# Altium schematic sheet 6-Bank6-9.schdoc(6-Bank6-9)
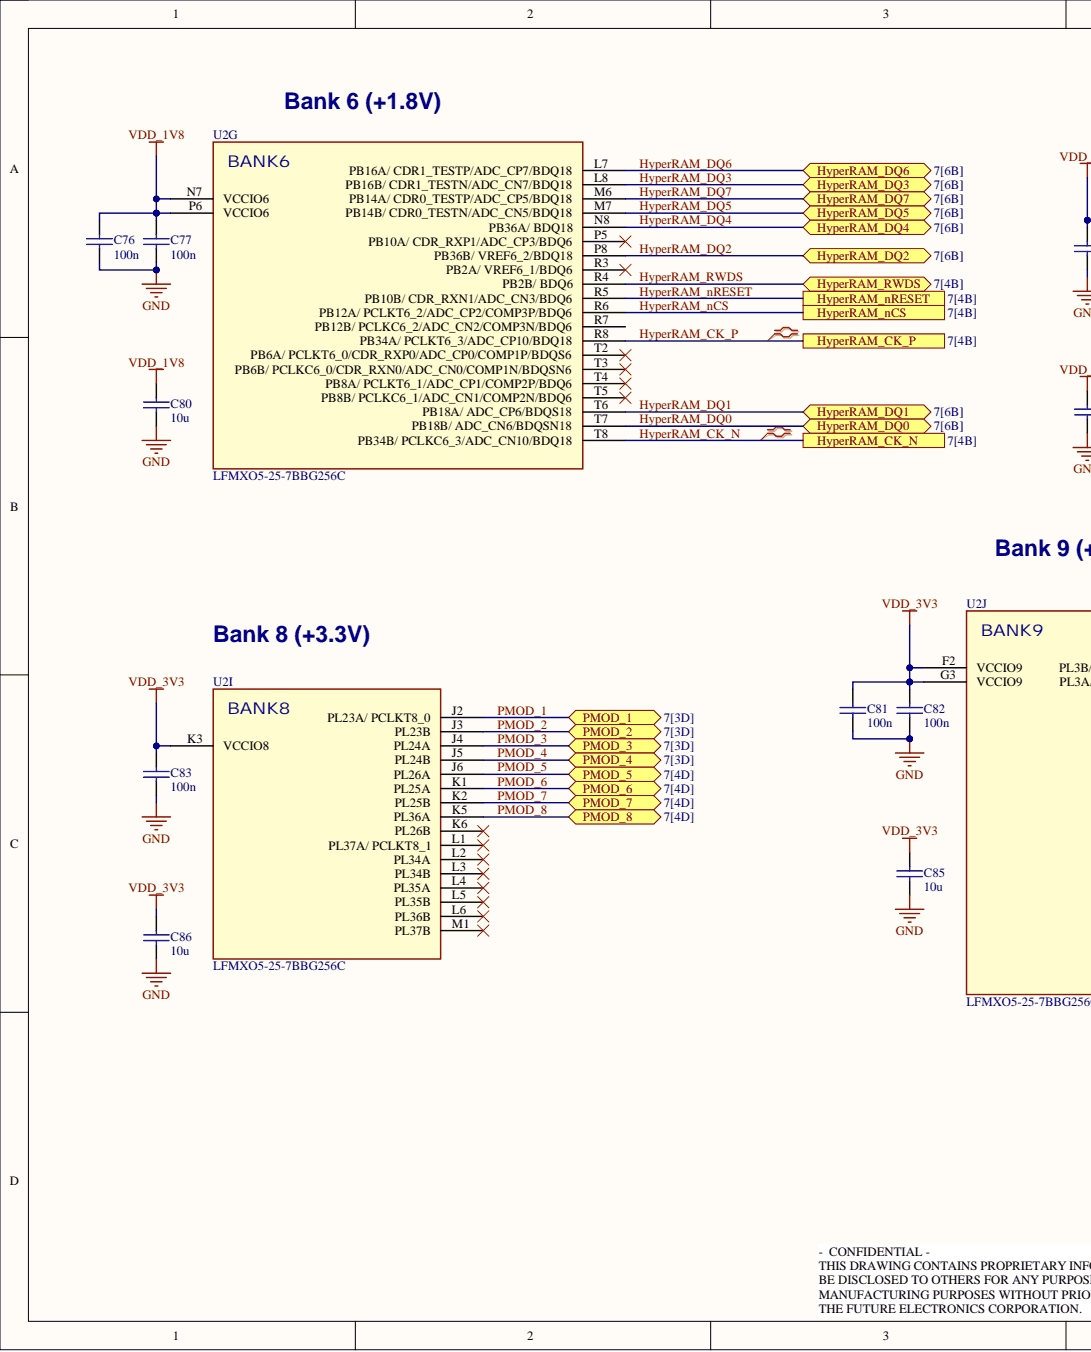
[diagram p0: 6-Bank6-9.schdoc, left]
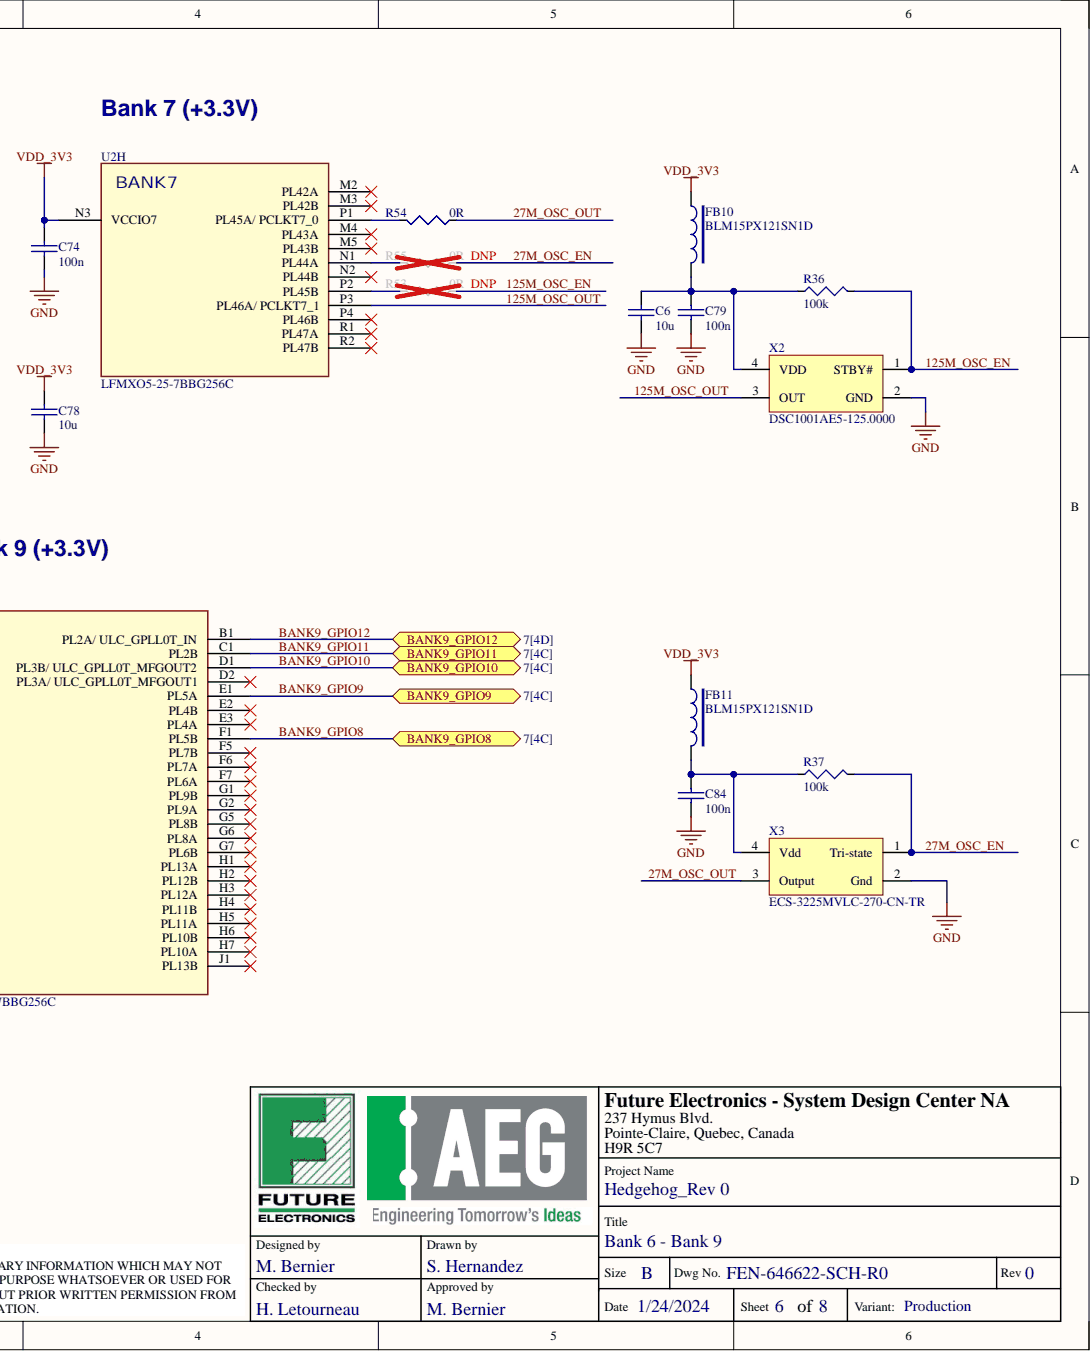
[diagram p1: 6-Bank6-9.schdoc, right]

## Parts
C6  value 10u  [1206]  (hidden comment: C-10uF-50V-Cer-1206_A)
C74  value 100n  [0402]  (hidden comment: C-100nF-50V-Cer-0402)
C76  value 100n  [0402]  (hidden comment: C-100nF-50V-Cer-0402)
C77  value 100n  [0402]  (hidden comment: C-100nF-50V-Cer-0402)
C78  value 10u  [1206]  (hidden comment: C-10uF-50V-Cer-1206_A)
C79  value 100n  [0402]  (hidden comment: C-100nF-50V-Cer-0402)
C80  value 10u  [1206]  (hidden comment: C-10uF-50V-Cer-1206_A)
C81  value 100n  [0402]  (hidden comment: C-100nF-50V-Cer-0402)
C82  value 100n  [0402]  (hidden comment: C-100nF-50V-Cer-0402)
C83  value 100n  [0402]  (hidden comment: C-100nF-50V-Cer-0402)
C84  value 100n  [0402]  (hidden comment: C-100nF-50V-Cer-0402)
C85  value 10u  [1206]  (hidden comment: C-10uF-50V-Cer-1206_A)
C86  value 10u  [1206]  (hidden comment: C-10uF-50V-Cer-1206_A)
FB10  MFR PN: BLM15PX121SN1D  [0402]  (hidden comment: FB-120Ohm@100MHz-0402)
FB11  MFR PN: BLM15PX121SN1D  [0402]  (hidden comment: FB-120Ohm@100MHz-0402)
R36  value 100k  [0402]  (hidden comment: R-100k-63mW-0402)
R37  value 100k  [0402]  (hidden comment: R-100k-63mW-0402)
R53  value 0R  [0402]  (hidden comment: R-0R-63mW-0402)
R54  value 0R  [0402]  (hidden comment: R-0R-63mW-0402)
R55  value 0R  [0402]  (hidden comment: R-0R-63mW-0402)
U2  MFR PN: LFMXO5-25-7BBG256C  [BBG256_LATTICE]  (hidden comment: U-LFMXO5-25-7BBG256C)  (parts G,H,I,J)
X2  MFR PN: DSC1001AE5-125.0000  [DFN4_MICROCHIP]  (hidden comment: X-DSC1001AE5-125.0000)
X3  MFR PN: ECS-3225MVLC-270-CN-TR  [ECS-3225MVLC]  (hidden comment: X-ECS-3225MVLC-270-CN-TR)

## Ports
BANK9_GPIO8, BANK9_GPIO9, BANK9_GPIO10, BANK9_GPIO11, BANK9_GPIO12, HyperRAM_CK_N, HyperRAM_CK_P, HyperRAM_DQ0, HyperRAM_DQ1, HyperRAM_DQ2, HyperRAM_DQ3, HyperRAM_DQ4, HyperRAM_DQ5, HyperRAM_DQ6, HyperRAM_DQ7, HyperRAM_nCS, HyperRAM_nRESET, HyperRAM_RWDS, PMOD_1, PMOD_2, PMOD_3, PMOD_4, PMOD_5, PMOD_6, PMOD_7, PMOD_8

## Nets
GND: C6.2 C74.2 C76.2 C77.2 C78.2 C79.2 C80.2 C81.2 C82.2 C83.2 C84.2 C85.2 C86.2 X2.2(GND) X3.2(Gnd)
VDD_1V8: C76.1 C77.1 C80.1 U2.N7(VCCIO6) U2.P6(VCCIO6)
VDD_3V3: C74.1 C78.1 C81.1 C82.1 C83.1 C85.1 C86.1 FB10.1 FB11.1 U2.F2(VCCIO9) U2.G3(VCCIO9) U2.K3(VCCIO8) U2.N3(VCCIO7)
27M_OSC_EN: R37.2 R55.1 X3.1(Tri-state)
27M_OSC_OUT: R54.1 X3.3(Output)
125M_OSC_EN: R36.2 R53.1 X2.1(STBY#)
125M_OSC_OUT: U2.P3(PL46A/_PCLKT7_1) X2.3(OUT)
BANK9_GPIO8: U2.F1(PL5B) ; port BANK9_GPIO8
BANK9_GPIO9: U2.E1(PL5A) ; port BANK9_GPIO9
BANK9_GPIO10: U2.D1 ; port BANK9_GPIO10
BANK9_GPIO11: U2.C1(PL2B) ; port BANK9_GPIO11
BANK9_GPIO12: U2.B1(PL2A/_ULC_GPLL0T_IN) ; port BANK9_GPIO12
HyperRAM_CK_N: U2.T8(PB34B/_PCLKC6_3/ADC_CN10/BDQ18) ; port HyperRAM_CK_N
HyperRAM_CK_P: U2.R8(PB34A/_PCLKT6_3/ADC_CP10/BDQ18) ; port HyperRAM_CK_P
HyperRAM_DQ0: U2.T7(PB18B/_ADC_CN6/BDQSN18) ; port HyperRAM_DQ0
HyperRAM_DQ1: U2.T6(PB18A/_ADC_CP6/BDQS18) ; port HyperRAM_DQ1
HyperRAM_DQ2: U2.P8(PB36B/_VREF6_2/BDQ18) ; port HyperRAM_DQ2
HyperRAM_DQ3: U2.L8(PB16B/_CDR1_TESTN/ADC_CN7/BDQ18) ; port HyperRAM_DQ3
HyperRAM_DQ4: U2.N8(PB36A/_BDQ18) ; port HyperRAM_DQ4
HyperRAM_DQ5: U2.M7 ; port HyperRAM_DQ5
HyperRAM_DQ6: U2.L7(PB16A/_CDR1_TESTP/ADC_CP7/BDQ18) ; port HyperRAM_DQ6
HyperRAM_DQ7: U2.M6 ; port HyperRAM_DQ7
HyperRAM_nCS: U2.R6(PB12A/_PCLKT6_2/ADC_CP2/COMP3P/BDQ6) ; port HyperRAM_nCS
HyperRAM_nRESET: U2.R5(PB10B/_CDR_RXN1/ADC_CN3/BDQ6) ; port HyperRAM_nRESET
HyperRAM_RWDS: U2.R4(PB2B/_BDQ6) ; port HyperRAM_RWDS
PMOD_1: U2.J2(PL23A/_PCLKT8_0) ; port PMOD_1
PMOD_2: U2.J3(PL23B) ; port PMOD_2
PMOD_3: U2.J4(PL24A) ; port PMOD_3
PMOD_4: U2.J5(PL24B) ; port PMOD_4
PMOD_5: U2.J6(PL26A) ; port PMOD_5
PMOD_6: U2.K1(PL25A) ; port PMOD_6
PMOD_7: U2.K2(PL25B) ; port PMOD_7
PMOD_8: U2.K5(PL36A) ; port PMOD_8
NetC6_1: C6.1 C79.1 FB10.2 R36.1 X2.4(VDD)
NetC84_1: C84.1 FB11.2 R37.1 X3.4(Vdd)
NetR53_2: R53.2 U2.P2(PL45B)
NetR54_2: R54.2 U2.P1(PL45A/_PCLKT7_0)
NetR55_2: R55.2 U2.N1(PL44A)

Not connected: U2 D2 E2(PL4B) E3(PL4A) F5(PL7B) F6(PL7A) F7(PL6A) G1(PL9B) G2(PL9A) G5(PL8B) G6(PL8A) G7(PL6B) H1(PL13A) H2(PL12B) H3(PL12A) H4(PL11B) H5(PL11A) H6(PL10B) H7(PL10A) J1(PL13B) K6(PL26B) L1(PL37A/_PCLKT8_1) L2(PL34A) L3(PL34B) L4(PL35A) L5(PL35B) L6(PL36B) M1(PL37B) M2(PL42A) M3(PL42B) M4(PL43A) M5(PL43B) N2(PL44B) P4(PL46B) P5(PB10A/_CDR_RXP1/ADC_CP3/BDQ6) R1(PL47A) R2(PL47B) R3(PB2A/_VREF6_1/BDQ6) R7(PB12B/_PCLKC6_2/ADC_CN2/COMP3N/BDQ6) T2(PB6A/_PCLKT6_0/CDR_RXP0/ADC_CP0/COMP1P/BDQS6) T3(PB6B/_PCLKC6_0/CDR_RXN0/ADC_CN0/COMP1N/BDQSN6) T4(PB8A/_PCLKT6_1/ADC_CP1/COMP2P/BDQ6) T5(PB8B/_PCLKC6_1/ADC_CN1/COMP2N/BDQ6)

## Library data of the parts
C6 C78 C80 C85 C86 = C-10uF-50V-Cer-1206_A: Cap 1206 SM X7R 50V 10%; MFR: SAMSUNG; MFR PN: CL31B106KBHNNNE; Future PN (CT): TBD; Future PN (TR): 12065C106KATN; Rating: 50V 10%; Component Kind: Standard; ComponentLink1Description: Datasheet; ComponentLink1URL: http://www.samsungsem.com/kr/support/product-search/mlcc/__icsFiles/afieldfile/2019/06/18/CL31B106KBHNNNE-19.pdf; Library Name: Future_Capacitors_V1.SCHLIB; Verified: AD112817
C74 C76 C77 C79 C81-C84 = C-100nF-50V-Cer-0402: Cap 0402 SM X7R 50V 10%; MFR: TDK; MFR PN: C1005X7R1H104K050BB; Future PN (CT): 04025C104KATN-CT; Future PN (TR): 04025C104KATN; Rating: 50V 10%; Component Kind: Standard; ComponentLink1Description: Datasheet; ComponentLink1URL: http://images.ihscontent.net/vipimages/VipMasterIC/IC/TDKC/TDKC-S-A0000443042/TDKC-S-A0000443042-1.pdf; Library Name: Future_Capacitors_V1.SCHLIB; Verified: AD042616
FB10 FB11 = FB-120Ohm@100MHz-0402: Inductor Ferrite Bead 0402 SM 2Art 55mOhmDC 120Ohm@100MHz; MFR: MURATA; Future PN (CT): TBD; VModel: MMDDYY; Component Kind: Standard; ComponentLink1Description: Datasheet; ComponentLink1URL: https://www.murata.com/en-us/products/productdata/8796740059166/ENFA0018.pdf; Future PN (TR): TBD; Rating: 2Art 55mOhmDC; Verified: TBD; Library Name: Hedgehog_Rev0.SchLib
R36 R37 = R-100k-63mW-0402: Res 0402 SM 63mW 1% 100ppm; MFR: YAGEO; MFR PN: RC0402FR-07100KL; Future PN (CT): CR0402-1003FTR-CT; Future PN (TR): CR0402-1003FTR; Rating: 63mW 1%; Component Kind: Standard; ComponentLink1Description: Datasheet; ComponentLink1URL: https://www.yageo.com/upload/media/product/products/datasheet/rchip/PYu-RC_Group_51_RoHS_L_10.pdf; Library Name: Future_Resistors_V1.SCHLIB
R53-R55 = R-0R-63mW-0402: Res 0402 SM 63mW; MFR: YAGEO; MFR PN: RC0402JR-070RL; Future PN (CT): CR0402-0000JTR-CT; Future PN (TR): CR0402-0000JTR; Component Kind: Standard; ComponentLink1Description: Datasheet; ComponentLink1URL: https://www.yageo.com/upload/media/product/products/datasheet/rchip/PYu-RC_Group_51_RoHS_L_10.pdf; Library Name: Future_Resistors_V1.SCHLIB; Rating: 63mW; Verified: AD062917
U2 = U-LFMXO5-25-7BBG256C: FPGA MachXO5-NX BBG256 SM 0.95~1.05Vcore 450MHz; MFR: LATTICE; Future PN (CT): TBD; VModel: MMDDYY; Component Kind: Standard; ComponentLink1Description: Datasheet; ComponentLink1URL: https://www.latticesemi.com/-/media/LatticeSemi/Documents/MachXO5-NX/FPGA-DS-02102-1-0-MachXO5-NX-Family.ashx?document_id=53488; Future PN (TR): TBD; Rating: 0.95~1.05Vcore 450MHz; Verified: TBD; Library Name: Hedgehog_Rev0.SchLib
X2 = X-DSC1001AE5-125.0000: Timing Oscillator DFN-4 SM 125MHz ±10ppm 15pF; MFR: MICROCHIP; Future PN (CT): TBD; Value: 125.000MHz; VModel: MMDDYY; Component Kind: Standard; ComponentLink1Description: Datasheet; ComponentLink1URL: https://rocelec.widen.net/view/pdf/4jp9qpy8qv/MCHP-S-A0003587227-1.pdf?t.download=true&u=5oefqw; Future PN (TR): TBD; Rating: ±10ppm 15pF; Verified: TBD; Library Name: Hedgehog_Rev0.SchLib
X3 = X-ECS-3225MVLC-270-CN-TR: Timing Oscillator 3.2x2.5mm SM 27MHz ±25ppm 15pF; MFR: ECS; Future PN (CT): TBD; Value: 27.000MHz; VModel: MMDDYY; Component Kind: Standard; ComponentLink1Description: Datasheet; ComponentLink1URL: https://ecsxtal.com/store/pdf/ECS-3225MVLC.pdf; Future PN (TR): TBD; Rating: ±25ppm 15pF; Verified: TBD; Library Name: Hedgehog_Rev0.SchLib
